# Altium schematic sheet Microcontroller.SchDoc(Microcontroller)
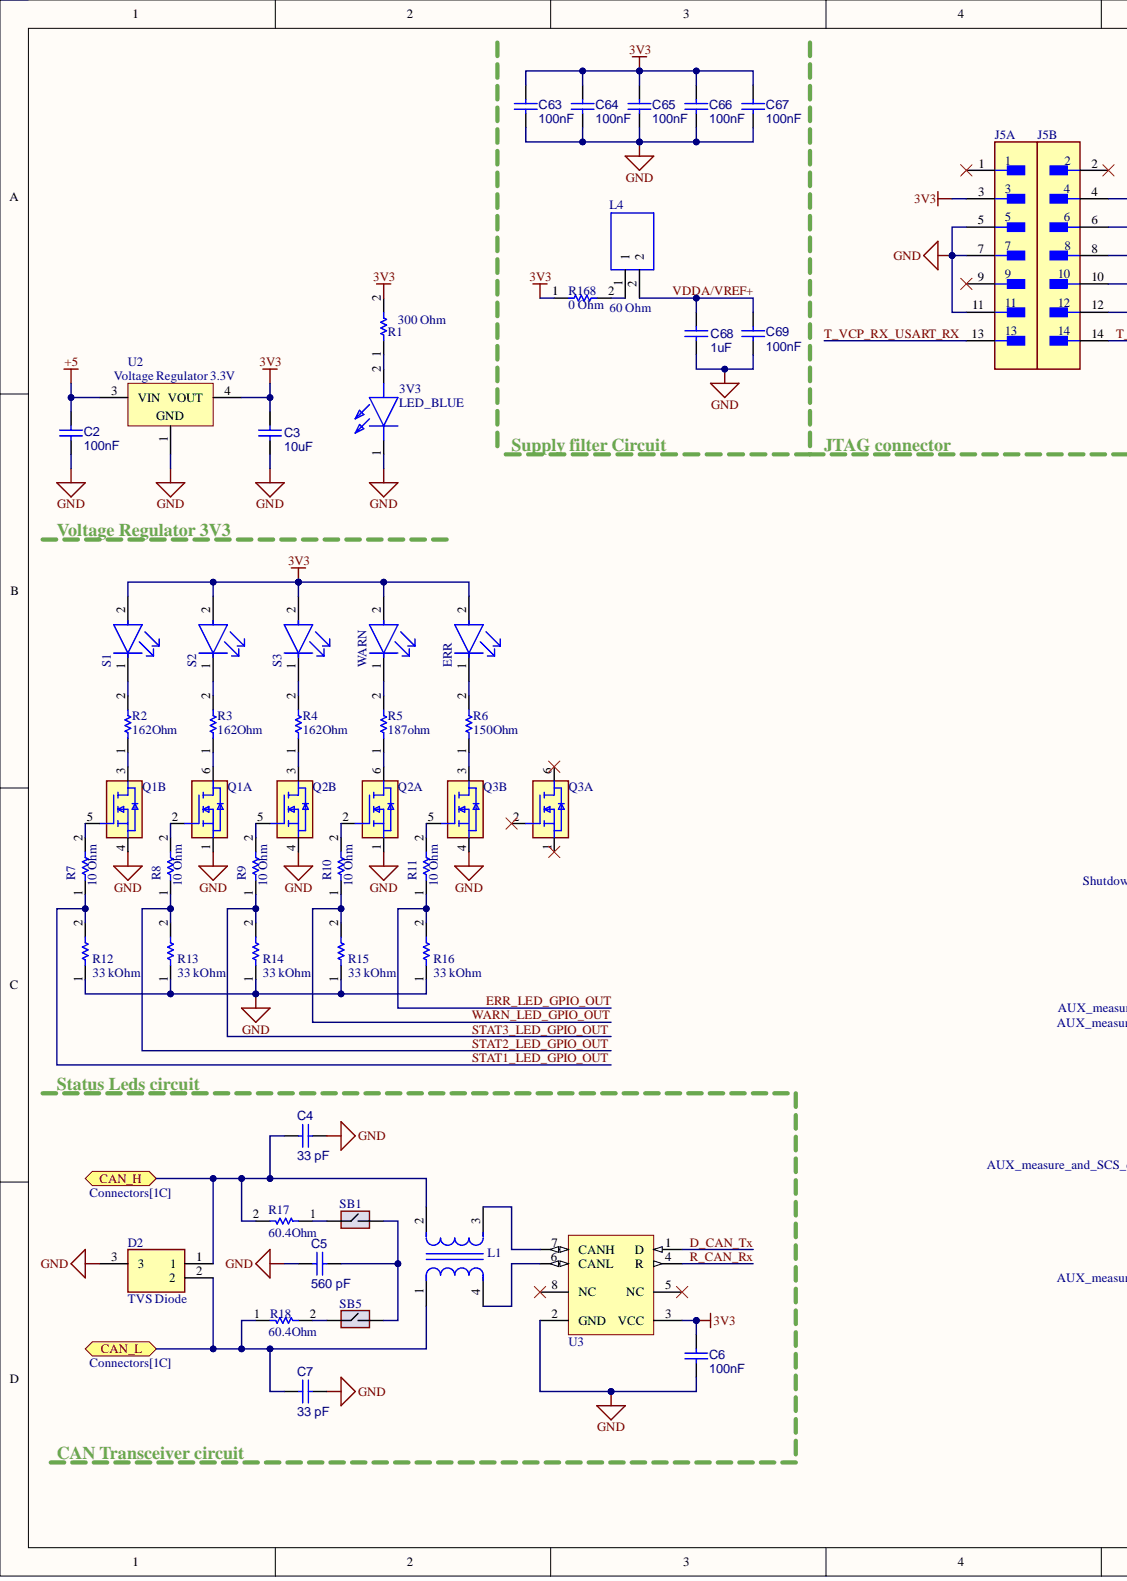
[diagram p0: Microcontroller.SchDoc, left]
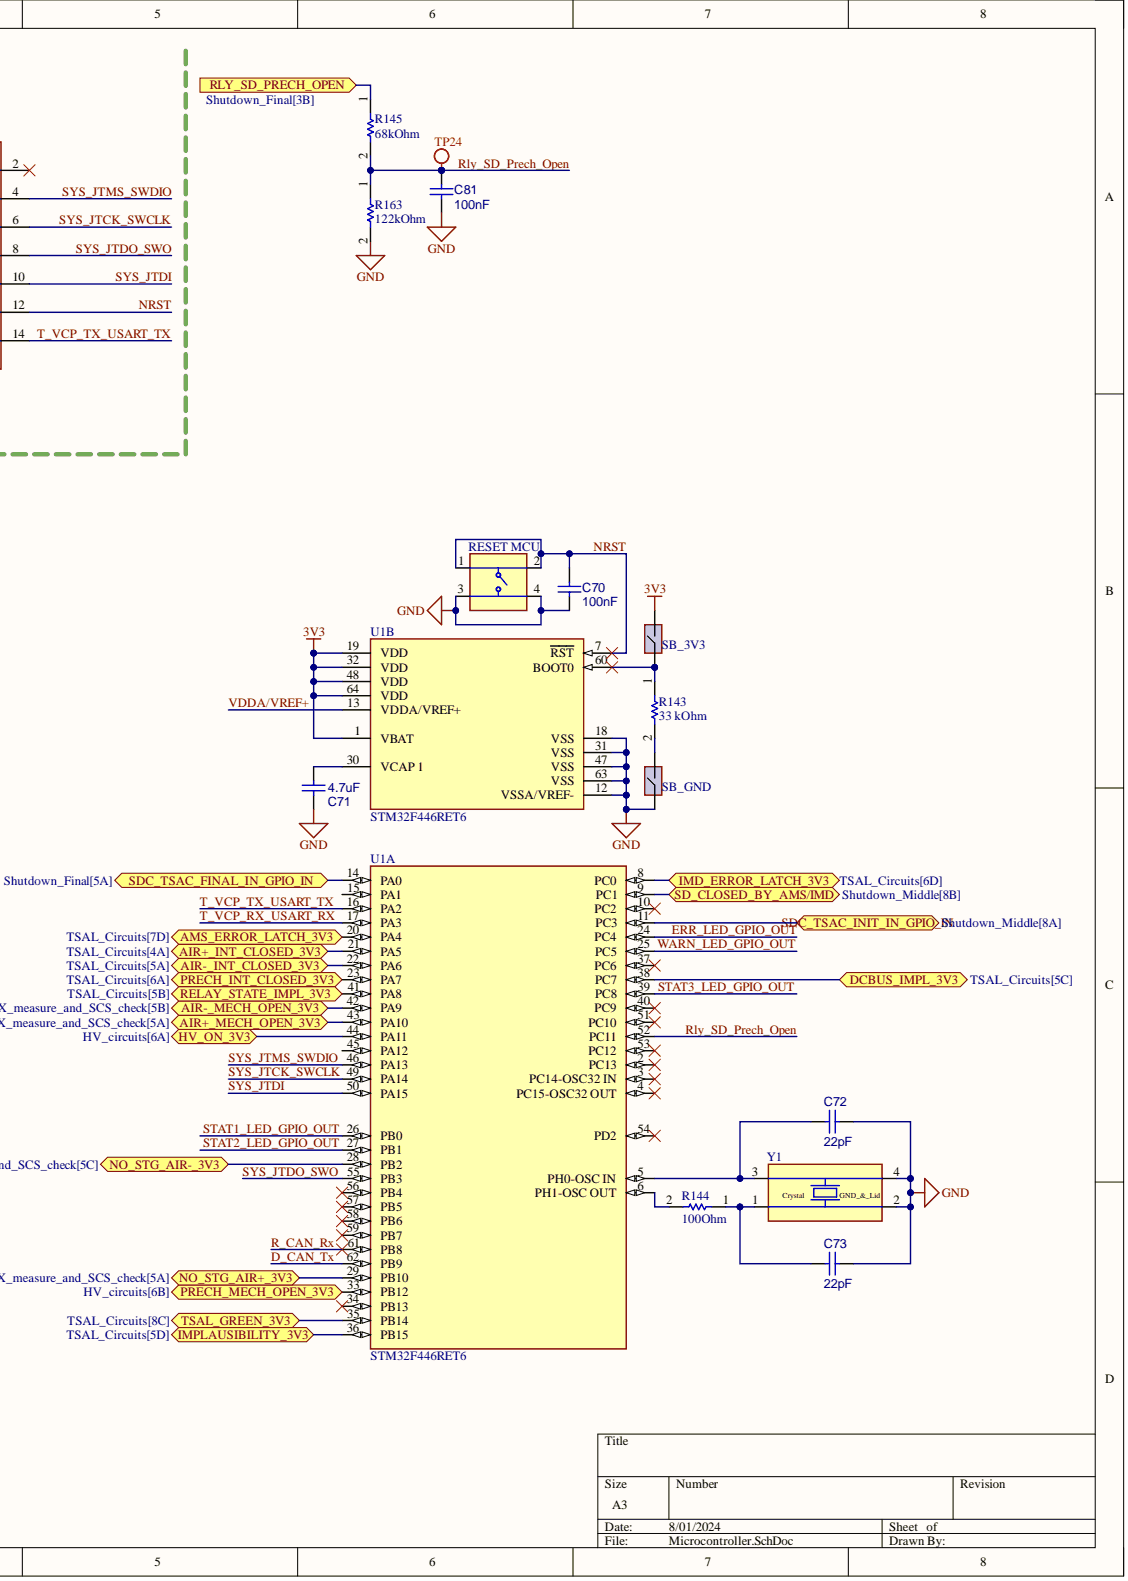
[diagram p1: Microcontroller.SchDoc, right]

## Parts
3V3  LED_BLUE
C2  100nF
C3  10uF
C4  33 pF
C5  560 pF
C6  100nF
C7  33 pF
C63  100nF
C64  100nF
C65  100nF
C66  100nF
C67  100nF
C68  1uF
C69  100nF
C70  100nF
C71  4.7uF
C72  22pF
C73  22pF
C81  100nF
D2  TVS Diode
ERR  RED  (value not drawn)
J5  FTSH-107-01-L-DV-K-A  (value not drawn)  (parts A,B)
L1  ACT45B-101-2P-TL003  (value not drawn)
L4  60 Ohm
Q1  SI1926DL-T1-GE3  (value not drawn)  (parts A,B)
Q2  SI1926DL-T1-GE3  (value not drawn)  (parts A,B)
Q3  SI1926DL-T1-GE3  (value not drawn)  (parts A,B)
R1  300 Ohm
R2  162Ohm
R3  162Ohm
R4  162Ohm
R5  187ohm
R6  150Ohm
R7  10 Ohm
R8  10 Ohm
R9  10 Ohm
R10  10 Ohm
R11  10 Ohm
R12  33 kOhm
R13  33 kOhm
R14  33 kOhm
R15  33 kOhm
R16  33 kOhm
R17  60.4Ohm
R18  60.4Ohm
R143  33 kOhm
R144  100Ohm
R145  68kOhm
R163  122kOhm
R168  0 Ohm
RESET_MCU  RKC2SJF170SMTR_LFS  (value not drawn)
S1  GREEN  (value not drawn)
S2  GREEN  (value not drawn)
S3  GREEN  (value not drawn)
SB1  SolderBridge_0805  (value not drawn)  (no BOM)
SB5  SolderBridge_0805  (value not drawn)  (no BOM)
SB_3V3  SolderBridge_0805  (value not drawn)  (no BOM)
SB_GND  SolderBridge_0805  (value not drawn)  (no BOM)
TP24  Test Point  (value not drawn)
U1  STM32F446RET6  (parts A,B)
U2  Voltage Regulator 3.3V
U3  SN65HVD232DRG4  [SN65HVD232]  (value not drawn)
WARN  YELLOW  (value not drawn)
Y1  830055663  (value not drawn)

## Ports
AIR+_INT_CLOSED_3V3, AIR+_MECH_OPEN_3V3, AIR-_INT_CLOSED_3V3, AIR-_MECH_OPEN_3V3, AMS_ERROR_LATCH_3V3, CAN_H, CAN_L, DCBUS_IMPL_3V3, HV_ON_3V3, IMD_ERROR_LATCH_3V3, IMPLAUSIBILITY_3V3, NO_STG_AIR+_3V3, NO_STG_AIR-_3V3, PRECH_INT_CLOSED_3V3, PRECH_MECH_OPEN_3V3, RELAY_STATE_IMPL_3V3, RLY_SD_PRECH_OPEN, SD_CLOSED_BY_AMS/IMD, SDC_TSAC_FINAL_IN_GPIO_IN, SDC_TSAC_INIT_IN_GPIO_IN, TSAL_GREEN_3V3

## Nets
3V3: C3.2 C6.1 C63.2 C64.2 C65.2 C66.2 C67.2 ERR.2 J5.3 R1.2 R168.1 S1.2 S2.2 S3.2 SB_3V3.2 U1.1(VBAT) U1.19(VDD) U1.32(VDD) U1.48(VDD) U1.64(VDD) U2.4 U3.3(VCC) WARN.2
+5: C2.2 U2.3
GND: 3V3.1 C2.1 C3.1 C4.1 C5.1 C6.2 C7.1 C63.1 C64.1 C65.1 C66.1 C67.1 C68.1 C69.1 C70.1 C71.1 C72.1 C73.2 C81.2 D2.3 J5.5 J5.7 J5.11 Q1.1 Q1.4 Q2.1 Q2.4 Q3.4 R12.1 R13.1 R14.1 R15.1 R16.1 R163.2 RESET_MCU.3 RESET_MCU.4 SB_GND.1 U1.12(VSSA/VREF-) U1.18(VSS) U1.31(VSS) U1.47(VSS) U1.63(VSS) U2.1 U3.2(GND) Y1.2 Y1.4
AMS_ERROR_LATCH_3V3: U1.20(PA4) ; port AMS_ERROR_LATCH_3V3
CAN_H: C4.2 D2.1 L1.2 R17.2 ; port CAN_H
CAN_L: C7.2 D2.2 L1.1 R18.1 ; port CAN_L
D_CAN_Tx: U1.62(PB9) U3.1(D)
DCBUS_IMPL_3V3: U1.38(PC7) ; port DCBUS_IMPL_3V3
ERR_LED_GPIO_OUT: R11.1 R16.2 U1.24(PC4)
HV_ON_3V3: U1.44(PA11) ; port HV_ON_3V3
IMD_ERROR_LATCH_3V3: U1.8(PC0) ; port IMD_ERROR_LATCH_3V3
IMPLAUSIBILITY_3V3: U1.36(PB15) ; port IMPLAUSIBILITY_3V3
NRST: C70.2 J5.12 RESET_MCU.1 RESET_MCU.2 U1.7(RST)
PRECH_INT_CLOSED_3V3: U1.23(PA7) ; port PRECH_INT_CLOSED_3V3
PRECH_MECH_OPEN_3V3: U1.33(PB12) ; port PRECH_MECH_OPEN_3V3
R_CAN_Rx: U1.61(PB8) U3.4(R)
RELAY_STATE_IMPL_3V3: U1.41(PA8) ; port RELAY_STATE_IMPL_3V3
RLY_SD_PRECH_OPEN: R145.1 ; port RLY_SD_PRECH_OPEN
Rly_SD_Prech_Open: C81.1 R145.2 R163.1 TP24.1 U1.52(PC11)
SD_CLOSED_BY_AMS/IMD: U1.9(PC1) ; port SD_CLOSED_BY_AMS/IMD
SDC_TSAC_FINAL_IN_GPIO_IN: U1.14(PA0) ; port SDC_TSAC_FINAL_IN_GPIO_IN
SDC_TSAC_INIT_IN_GPIO_IN: U1.11(PC3) ; port SDC_TSAC_INIT_IN_GPIO_IN
STAT1_LED_GPIO_OUT: R7.1 R12.2 U1.26(PB0)
STAT2_LED_GPIO_OUT: R8.1 R13.2 U1.27(PB1)
STAT3_LED_GPIO_OUT: R9.1 R14.2 U1.39(PC8)
SYS_JTCK_SWCLK: J5.6 U1.49(PA14)
SYS_JTDI: J5.10 U1.50(PA15)
SYS_JTDO_SWO: J5.8 U1.55(PB3)
SYS_JTMS_SWDIO: J5.4 U1.46(PA13)
T_VCP_RX_USART_RX: J5.13 U1.17(PA3)
T_VCP_TX_USART_TX: J5.14 U1.16(PA2)
TSAL_GREEN_3V3: U1.35(PB14) ; port TSAL_GREEN_3V3
VDDA/VREF+: C68.2 C69.2 L4.2 U1.13(VDDA/VREF+)
WARN_LED_GPIO_OUT: R10.1 R15.2 U1.25(PC5)
Net3V3_2: 3V3.2 R1.1
NetC5_2: C5.2 SB1.2 SB5.2
NetC71_2: C71.2 U1.30(VCAP_1)
NetC72_2: C72.2 U1.5(PH0-OSC_IN) Y1.3
NetC73_1: C73.1 R144.1 Y1.1
NetERR_1: ERR.1 R6.2
NetL1_3: L1.3 U3.7(CANH)
NetL1_4: L1.4 U3.6(CANL)
NetL4_1: L4.1 R168.2
NetQ1_2: Q1.2 R8.2
NetQ1_3: Q1.3 R2.1
NetQ1_5: Q1.5 R7.2
NetQ1_6: Q1.6 R3.1
NetQ2_2: Q2.2 R10.2
NetQ2_3: Q2.3 R4.1
NetQ2_5: Q2.5 R9.2
NetQ2_6: Q2.6 R5.1
NetQ3_3: Q3.3 R6.1
NetQ3_5: Q3.5 R11.2
NetR2_2: R2.2 S1.1
NetR3_2: R3.2 S2.1
NetR4_2: R4.2 S3.1
NetR5_2: R5.2 WARN.1
NetR17_1: R17.1 SB1.1
NetR18_2: R18.2 SB5.1
NetR143_1: R143.1 SB_3V3.1 U1.60(BOOT0)
NetR143_2: R143.2 SB_GND.2
NetR144_2: R144.2 U1.6(PH1-OSC_OUT)
NetU1_21: U1.21(PA5)
NetU1_22: U1.22(PA6)
NetU1_28: U1.28(PB2)
NetU1_29: U1.29(PB10)
NetU1_42: U1.42(PA9)
NetU1_43: U1.43(PA10)

Not connected: J5 1 2 9; Q3 1 2 6; U1 2(PC13) 3(PC14-OSC32_IN) 4(PC15-OSC32_OUT) 10(PC2) 15(PA1) 34(PB13) 37(PC6) 40(PC9) 45(PA12) 51(PC10) 53(PC12) 54(PD2) 56(PB4) 57(PB5) 58(PB6) 59(PB7); U3 5(NC) 8(NC)

## Library data of the parts
3V3 = CMP-031-000016-1: APT2012LVBC_D; Manufacturer_Name: Kingbright; Manufacturer_Part_Number: APT2012LVBC/D; Tech: SMD; Arrow Part Number: APT2012LVBC/D; Arrow Price/Stock: https://www.arrow.com/en/products/apt2012lvbcd/kingbright; Component Kind: Standard; ComponentLink1Description: Website; ComponentLink1URL: https://www.mouser.it/ProductDetail/Kingbright/APT2012LVBC-D?qs=6oMev5NRZMGErJYT6KZLXg%3D%3D; Datasheet Link: https://componentsearchengine.com/Datasheets/1/APT2012LVBC_D.pdf; Design Item ID: APT2012LVBC_D; Height: 0.85mm; Mouser Part Number: 604-APT2012LVBCD; Mouser Price/Stock: https://www.mouser.co.uk/ProductDetail/Kingbright/APT2012LVBC-D?qs=6oMev5NRZMGErJYT6KZLXg%3D%3D; Package: 0805; Source: SamacSys.SchLib; Library Name: EMEA- Squadra Corse PoliTO-  Politecnico di Torino
C2 C63-C67 C69 C70 = CMP-001-00085-5: VJ0805Y104KBABE31; Tech: SMD; Component Kind: Standard; ComponentLink1Description: Website; Package: 0805; Library Name: EMEA- Squadra Corse PoliTO-  Politecnico di Torino
C3 = CMP-001-00085-5: GRM21BR71A106KA73K; Tech: SMD; Component Kind: Standard; ComponentLink1Description: Website; Package: 0805; Library Name: EMEA- Squadra Corse PoliTO-  Politecnico di Torino
C4 C7 = CMP-001-00085-5: VJ0805A330JXACW1BC; Tech: SMD; Component Kind: Standard; ComponentLink1Description: Website; Package: 0805; Library Name: EMEA- Squadra Corse PoliTO-  Politecnico di Torino
C5 = CMP-001-00085-5: VJ0805Y561KXACW1BC; Tech: SMD; Component Kind: Standard; ComponentLink1Description: Website; Package: 0805; Library Name: EMEA- Squadra Corse PoliTO-  Politecnico di Torino
C6 C81 = CMP-001-00085-5: VJ0805Y104MXATW1BC; Tech: SMD; Component Kind: Standard; ComponentLink1Description: Website; Package: 0805; Library Name: EMEA- Squadra Corse PoliTO-  Politecnico di Torino
C68 = CMP-001-00085-5: VJ0805Y105KXXTW1BC; Tech: SMD; Component Kind: Standard; ComponentLink1Description: Website; Package: 0805; Library Name: EMEA- Squadra Corse PoliTO-  Politecnico di Torino
C71 = CMP-001-00085-5: GCJ21BR71C475KA01L; Tech: SMD; Component Kind: Standard; ComponentLink1Description: Website; Package: 0805; Library Name: EMEA- Squadra Corse PoliTO-  Politecnico di Torino
C72 C73 = CMP-001-00085-5: VJ0805A220KXACW1BC; Tech: SMD; Component Kind: Standard; ComponentLink1Description: Website; Package: 0805; Library Name: EMEA- Squadra Corse PoliTO-  Politecnico di Torino
D2 = CMP-030-000036-3: ESDCAN24-2BLY; Tech: SMD; Component Kind: Standard; Package: SOT-23-3; Library Name: EMEA- Squadra Corse PoliTO-  Politecnico di Torino
ERR = CMP-007-00036-2: VLMS1300-GS08; Tech: SMD; Component Kind: Standard; Package: 0603; Library Name: EMEA- Squadra Corse PoliTO-  Politecnico di Torino
J5 = CMP-02766-001414-1: CONN HEADER SMD 14POS 1.27MM; Gender: Male; Number of Contacts: 14; Component Kind: Standard; Contact Material: Bronze; Contact Plating: Gold; Lead Free: Lead Free; Library Name: Altium Content Vault; Number of Rows: 2; Pitch: 1.27mm; RoHS Compliant: Yes; Termination: Solder
L1 = CMP-08256-000007-1: CMC 100UH 150MA 2LN SMD AEC-Q200; Manufacturer: TDK; Automotive Grade: Grade 0; Impedance At Frequency: 5800Ω @ 10MHz; Rms Current: 0.15A; Series Resistance: 2Ω; Test Frequency: 100kHz; Tolerance: +50/-30%; Automotive: Yes; Category: Ind; Component Kind: Standard; ComponentLink2Description: Datasheet; ComponentLink2URL: https://product.tdk.com/info/en/catalog/datasheets/cmf_automotive_signal_act45b_en.pdf; Composition: Wirewound; Device Class L1: Passive Components; Device Class L2: EMI / RFI Components; Device Class L3: Common Mode Chokes; Digikey Description: CMC 100UH 150MA 2LN SMD AEC-Q200; Height: 3mm; Inductance: 100uH; Lead Free: Yes; Library Name: Altium Content Vault; Manufacturer Part Number: ACT45B-101-2P-TL003; Mouser Description: Common Mode Chokes / Filters 5000ohms 100uH 150mA CAN-BUS AEC-Q200; Octopart: https://octopart.com/act45b-101-2p-tl003-tdk-11353565; Package: ACT45B; RoHS: TRUE; Temperature: -40°C to +150°C; Temperature Range High: +150°C; Temperature Range Low: -40°C; Value: 100uH
L4 = CMP-056-000000-2: Ferrite Beads Multi-Layer High Current 60Ohm 25% 100MHz 3A 30mOhm DCR 0805 T/R; Manufacturer_Name: Vishay; Manufacturer_Part_Number: ILHB0805ER600V; Geometry.Height: 1.1mm; Component Kind: Standard; Datasheet Link: https://www.arrow.com/en/products/ilhb0805er600v/vishay; Design Item ID: ILHB0805ER600V; Mouser Part Number: 70-ILHB0805ER600V; Mouser Price/Stock: https://www.mouser.co.uk/ProductDetail/Vishay-Dale/ILHB0805ER600V?qs=nDC8RkArV2CRAw2z9yWdfQ%3D%3D; Source: ILHB0805ER600V.SchLib; Library Name: EMEA- Squadra Corse PoliTO-  Politecnico di Torino
Q1-Q3 = CMP-009-000004-2: MOSFET (N-Channel); Manufacturer_Name: Vishay; Arrow Part Number: SI1926DL-T1-GE3; Arrow Price/Stock: https://www.arrow.com/en/products/si1926dl-t1-ge3/vishay; Component Kind: Standard; Datasheet Link: https://www.vishay.com/docs/73684/si1926dl.pdf; Design Item ID: SI1926DL-T1-GE3; Height: 1.1mm; Manufacturer_Part_Number: SI1926DL-T1-GE3; Mouser Part Number: 78-SI1926DL-T1-GE3; Mouser Price/Stock: https://www.mouser.com/Search/Refine.aspx?Keyword=78-SI1926DL-T1-GE3; Source: SamacSys.SchLib; Library Name: EMEA- Squadra Corse PoliTO-  Politecnico di Torino
R1 = CMP-009-00179-5: CRCW0603300RFKEA; Tech: SMD; Component Kind: Standard; ComponentLink1Description: Website; Package: 0603; Library Name: EMEA- Squadra Corse PoliTO-  Politecnico di Torino
R2-R4 = CMP-009-00179-5: CRCW0603162RFKEA; Tech: SMD; Component Kind: Standard; ComponentLink1Description: Website; Package: 0603; Library Name: EMEA- Squadra Corse PoliTO-  Politecnico di Torino
R5 = CMP-009-00179-5: CRCW0603187RFKEA; Tech: SMD; Component Kind: Standard; ComponentLink1Description: Website; Package: 0603; Library Name: EMEA- Squadra Corse PoliTO-  Politecnico di Torino
R6 = CMP-009-00179-5: CRCW0603150RFKEAHP; Tech: SMD; Component Kind: Standard; ComponentLink1Description: Website; Package: 0603; Library Name: EMEA- Squadra Corse PoliTO-  Politecnico di Torino
R7-R11 = CMP-009-00179-5: CRCW060310R0FKEAHP; Tech: SMD; Component Kind: Standard; ComponentLink1Description: Website; Package: 0603; Library Name: EMEA- Squadra Corse PoliTO-  Politecnico di Torino
R12-R16 R143 = CMP-009-00179-5: RCS060333K0FKEA; Tech: SMD; Component Kind: Standard; ComponentLink1Description: Website; Package: 0603; Library Name: EMEA- Squadra Corse PoliTO-  Politecnico di Torino
R17 R18 = CMP-009-00179-5: CRCW060360R4FKEA; Tech: SMD; Component Kind: Standard; ComponentLink1Description: Website; Package: 0603; Library Name: EMEA- Squadra Corse PoliTO-  Politecnico di Torino
R144 = CMP-009-00179-5: CRCW0603100RFKEBC; Tech: SMD; Component Kind: Standard; ComponentLink1Description: Website; Package: 0603; Library Name: EMEA- Squadra Corse PoliTO-  Politecnico di Torino
R145 = CMP-009-00179-5: CRCW060368K0FKEAC; Tech: SMD; Component Kind: Standard; ComponentLink1Description: Website; Package: 0603; Library Name: EMEA- Squadra Corse PoliTO-  Politecnico di Torino
R163 = CMP-009-00179-5: CRCW0603121KFKEA; Tech: SMD; Component Kind: Standard; ComponentLink1Description: Website; Package: 0603; Library Name: EMEA- Squadra Corse PoliTO-  Politecnico di Torino
R168 = CMP-009-00179-5: CRCW12060000Z0EC; Tech: SMD; Component Kind: Standard; ComponentLink1Description: Website; Package: 0603; Library Name: EMEA- Squadra Corse PoliTO-  Politecnico di Torino
RESET_MCU = CMP-011-000004-5: Tactile Switches; Manufacturer_Name: C & K COMPONENTS; Manufacturer_Part_Number: RKC2SJF170SMTR LFS; Arrow Part Number: RKC2SJF170SMTR LFS; Arrow Price/Stock: https://www.arrow.com/en/products/rkc2sjf170smtr-lfs/ck?region=nac; Component Kind: Standard; Datasheet Link: https://www.ckswitches.com/media/3470/ck_rkc2_datasheet.pdf; Design Item ID: RKC2SJF170SMTR_LFS; Height: 1.9mm; Mouser Part Number: 611-RKC2SJF170SMRLFS; Mouser Price/Stock: https://www.mouser.co.uk/ProductDetail/CK/RKC2SJF170SMTR-LFS?qs=XAiT9M5g4x%252BRRI9derenng%3D%3D; Source: SamacSys.SchLib; Library Name: EMEA- Squadra Corse PoliTO-  Politecnico di Torino
S1-S3 = CMP-007-00036-2: VLMTG1401-GS08; Tech: SMD; Component Kind: Standard; Package: 0603; Library Name: EMEA- Squadra Corse PoliTO-  Politecnico di Torino
SB1 SB5 SB_3V3 SB_GND = CMP-00006-5: 0805 Solder Bridge; Library Name: EMEA- Squadra Corse PoliTO-  Politecnico di Torino
TP24 = CMP-002-00071-2: Component Kind: Standard; Description: Test Point; Library Name: EMEA- Squadra Corse PoliTO-  Politecnico di Torino
U1 = CMP-12105-000007-1: IC MCU 32BIT 512KB FLASH 64LQFP; Manufacturer: ST; Core Architecture: ARM Cortex-M4; Data Bus Width: 32bits; Frequency: 180MHz; Interface: I2C,SPI,UART,USART,CAN,USB,LIN,IrDA,I2S,Other; Max Junction Temp: +105°C; Max Supply Voltage: 3.6V; Memory Size: 512KBytes; Min Supply Voltage: 1.7V; Number Of A/D Converters: 3; Number Of Adc Channels: 16; Number Of Cores: 1; Number Of I2C Channels: 4; Number Of I/Os: 50; Number Of Pwm Channels: 32; Number Of Timers/Counters: 14; Number Of Uart Channels: 6; Number Of Usb Channels: 2; Ram Size: 128KBytes; Standoff Height: 0.05mm; Ambient Temperature Range High: +85°C; Ambient Temperature Range Low: -40°C; Automotive: No; Category: IC; Component Kind: Standard; ComponentLink2Description: Datasheet; ComponentLink2URL: https://www.st.com/en/microcontrollers-microprocessors/stm32f446re.html; Device Class L1: Integrated Circuits (ICs); Device Class L2: Embedded Processors and Controllers; Device Class L3: Microcontrollers; Digikey Description: IC MCU 32BIT 512KB FLASH 64LQFP; Height: 1.6mm; Ipc Land Pattern Name: QFP50P1200X1200X160-64; Lead Free: Yes; Library Name: Altium Content Vault; Manufacturer Part Number: STM32F446RET6; Memory Type: FLASH; Mouser Description: ARM Microcontrollers - MCU High-performance and DSP with FP, ARM Cortex-M4 MCU with 512 Kbytes Flash, 180 MHz CPU, Art Accelerator, SDRAM; Number Of Spi Channels: 4; Octopart: https://octopart.com/stm32f446ret6-stmicroelectronics-52642920; Package: LQFP64-5W; RoHS: TRUE; Temperature: -40°C to +105°C; Temperature Range High: +105°C; Temperature Range Low: -40°C
U2 = CMP-0244-00089-1: LD1117DT33CTR; Tech: SMD; Component Kind: Standard; ComponentLink1Description: Manufacturer URL; ComponentLink1URL: http://www.st.com; ComponentLink2Description: Datasheet; ComponentLink2URL: http://www.st.com/internet/com/TECHNICAL_RESOURCES/TECHNICAL_LITERATURE/DATASHEET/CD00000544.pdf; ComponentLink3Description: Website; ComponentLink3URL: https://www.mouser.it/ProductDetail/STMicroelectronics/LD1117DT33CTR?qs=rUxiu3TV3%2FNm%2FOyb7vJzpg%3D%3D; DatasheetVersion: 1/11/2011; Library Name: Altium Content Vault; PackageDescription: 3-Pin Transistor Outline Package 2.28 mm Pitch, 9.7 mm Lead Span (DPAK); PackageReference: TO-252; PackageVersion: 2011
U3 = CMP-0315-00080-1: 3.3 V Can Transceiver, 17 mA, -40 to 85 degC, 8-pin SOIC (D), Green (RoHS & no Sb/Br); Manufacturer: Texas Instruments; Drivers Per Package: 1; ICC(Max)(mA): 17; Rating: Catalog; Supply Voltage(s)(V): 3.3; Transient Pulse Protection(V): -25 to 25; Code_JEDEC: MS-012AA; Component Kind: Standard; ComponentLink1Description: Datasheet; ComponentLink1URL: http://www.ti.com/general/docs/lit/getliterature.tsp?genericPartNumber=SN65HVD232&fileType=pdf; DatasheetVersion: SLOS346K; Eco-Plan: Green (RoHS & no Sb/Br); Isolated: No; Library Name: Altium Content Vault; Mounting Technology: Surface Mount; PackageDescription: 8-Pin Plastic Small Outline Integrated Circuit, 4.9 x 3.9 mm, Pitch 1.27 mm Pitch; PackageReference: D8; PackageVersion: revM, Jun-2011; PartNumber: SN65HVD232DRG4; Receivers Per Package: 1; SubFamily: CAN
WARN = CMP-007-00036-2: VLMG1300-GS08; Tech: SMD; Component Kind: Standard; Package: 0603; Library Name: EMEA- Squadra Corse PoliTO-  Politecnico di Torino
Y1 = CMP-004-000011-5: Crystals WE-XTAL 8.0MHz 30ppm 5.0 x 3.2mm; Manufacturer_Name: Wurth Elektronik; Component Kind: Standard; Datasheet Link: https://www.we-online.com/catalog/datasheet/830055663.pdf; Design Item ID: 830055663; Height: 1mm; Manufacturer_Part_Number: 830055663; Mouser Part Number: 710-830055663; Mouser Price/Stock: https://www.mouser.co.uk/ProductDetail/Wurth-Elektronik/830055663?qs=2WXlatMagcHXGqDkS%2FTHKQ%3D%3D; Source: SamacSys.SchLib; Library Name: EMEA- Squadra Corse PoliTO-  Politecnico di Torino
